# Altium schematic sheet SD-1_Schematic.SchDoc
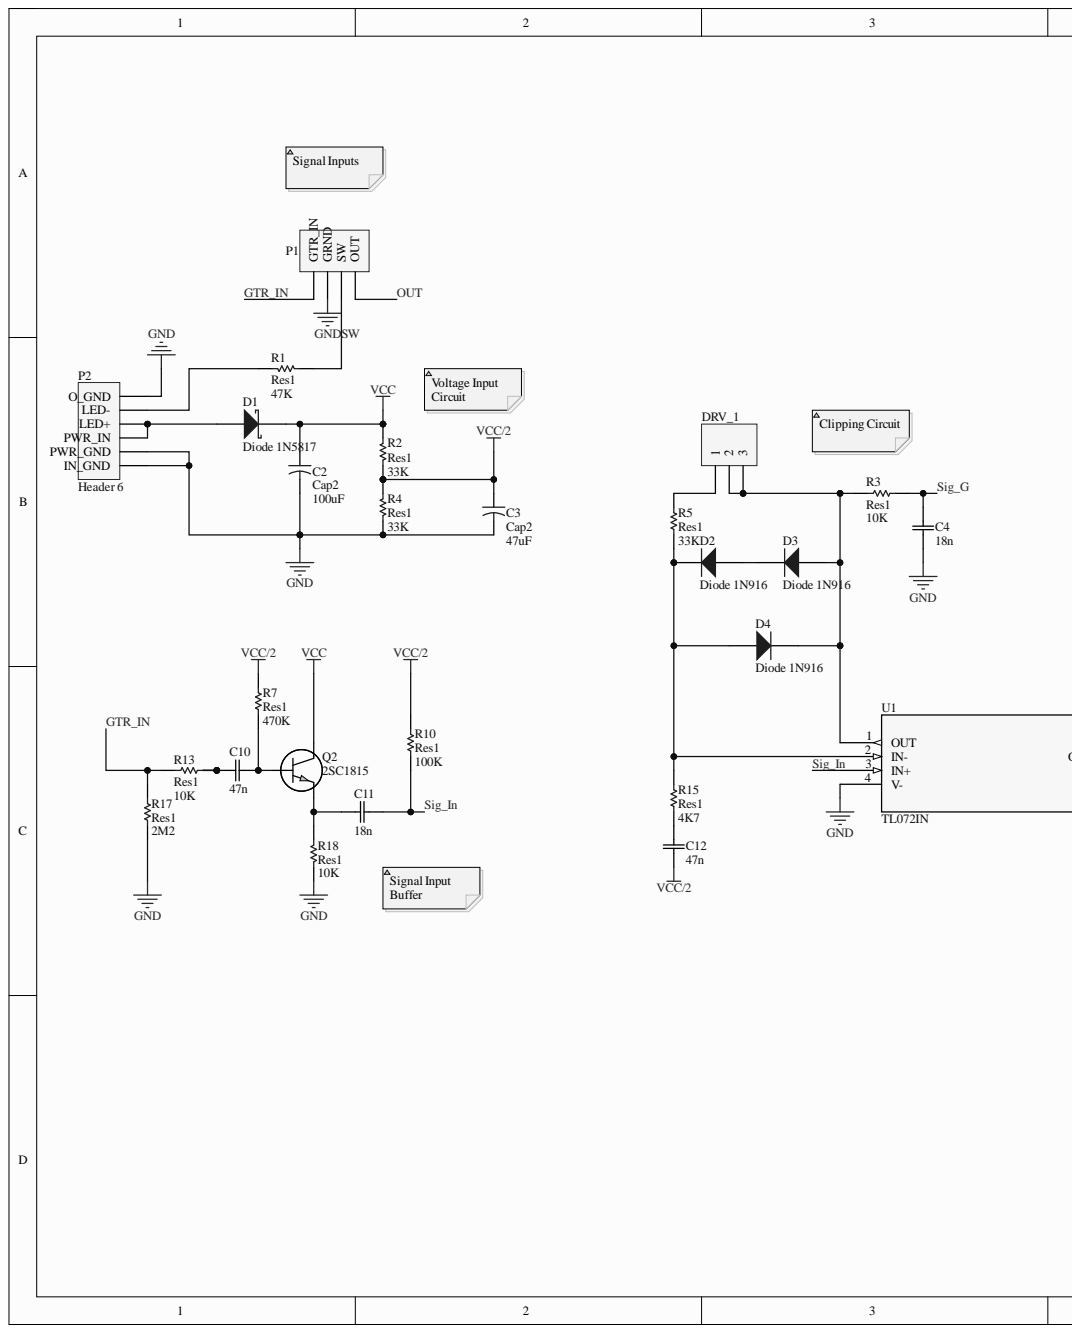
[diagram p0: SD-1_Schematic.SchDoc, left]
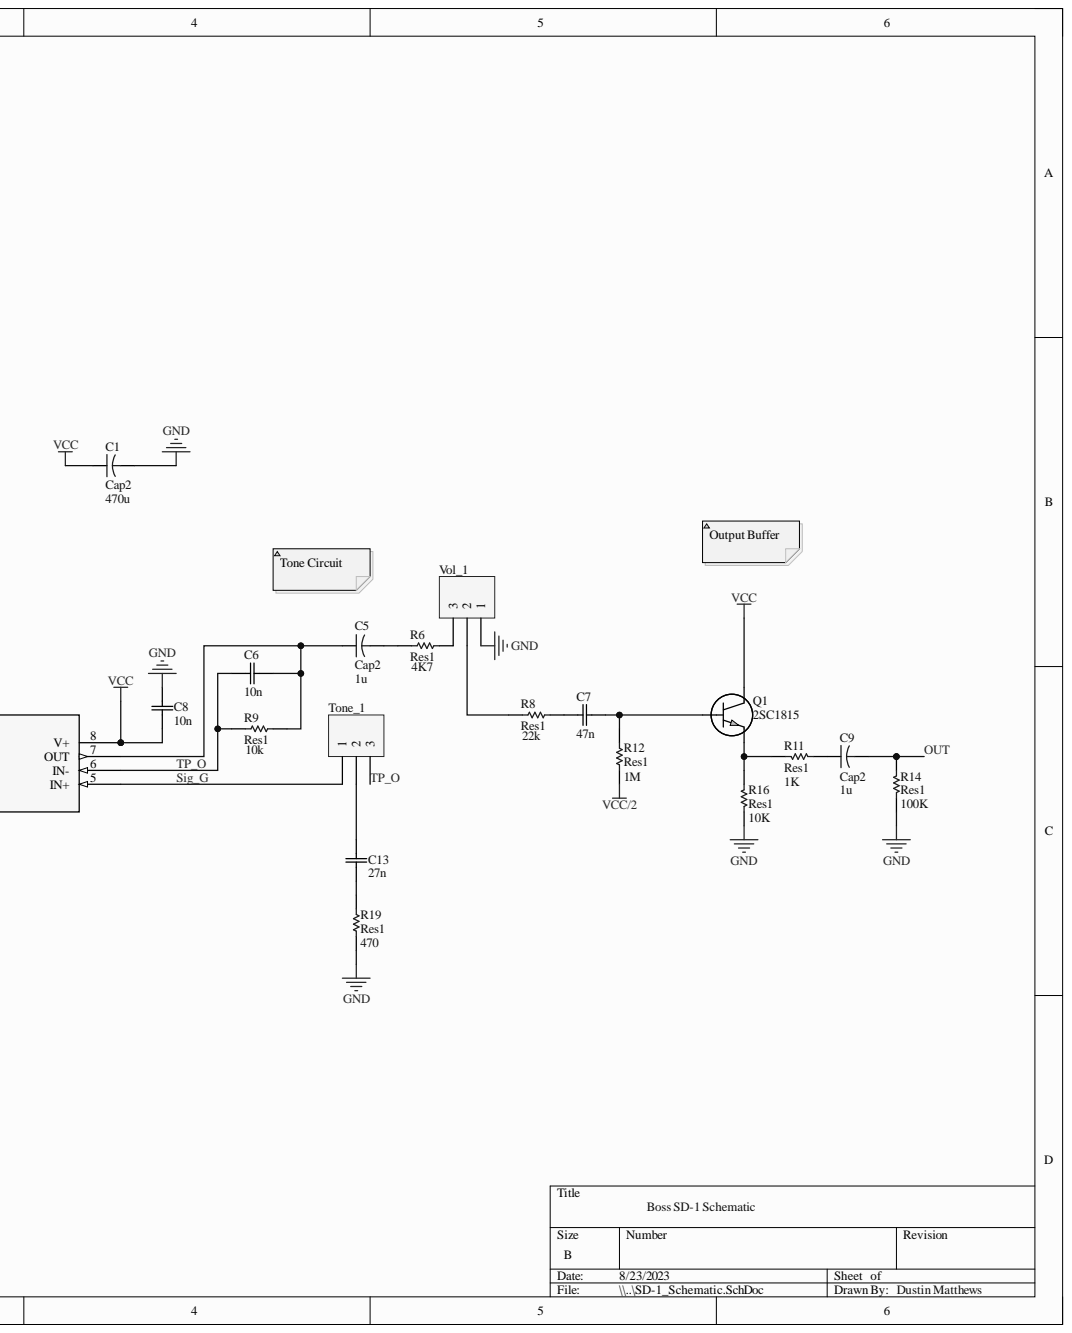
[diagram p1: SD-1_Schematic.SchDoc, right]

## Parts
C1  Cap2  value 470u  [CAPR5-4X5]
C2  Cap2  value 100uF  [CAPR5-4X5]
C3  Cap2  value 47uF  [CAPR5-4X5]
C4  18n  [CAP_B32529_04.5X09.5X07.3_TDK]
C5  Cap2  value 1u  [CAPR5-4X5]
C6  10n  [CAP_B32529_04.5X09.5X07.3_TDK]
C7  47n  [CAP_B32529_04.5X09.5X07.3_TDK]
C8  10n  [CAP_B32529_04.5X09.5X07.3_TDK]
C9  Cap2  value 1u  [CAPR5-4X5]
C10  47n  [CAP_B32529_04.5X09.5X07.3_TDK]
C11  18n  [CAP_B32529_04.5X09.5X07.3_TDK]
C12  47n  [CAP_B32529_04.5X09.5X07.3_TDK]
C13  27n  [CAP_B32529_04.5X09.5X07.3_TDK]
D1  Diode 1N5817  [DO-204AL]
D2  Diode 1N916  [DO-35]
D3  Diode 1N916  [DO-35]
D4  Diode 1N916  [DO-35]
DRV_1  Header 3  [HDR1X3]  (value not drawn)
P1  Header 4  [HDR1X4]  (value not drawn)
P2  Header 6  [HDR1X6]
Q1  2SC1815  [TO-92_4P2X5P2_CNS]
Q2  2SC1815  [TO-92_4P2X5P2_CNS]
R1  Res1  value 47K  [AXIAL-0.3]
R2  Res1  value 33K  [AXIAL-0.3]
R3  Res1  value 10K  [AXIAL-0.3]
R4  Res1  value 33K  [AXIAL-0.3]
R5  Res1  value 33K  [AXIAL-0.3]
R6  Res1  value 4K7  [AXIAL-0.3]
R7  Res1  value 470K  [AXIAL-0.3]
R8  Res1  value 22k  [AXIAL-0.3]
R9  Res1  value 10k  [AXIAL-0.3]
R10  Res1  value 100K  [AXIAL-0.3]
R11  Res1  value 1K  [AXIAL-0.3]
R12  Res1  value 1M  [AXIAL-0.3]
R13  Res1  value 10K  [AXIAL-0.3]
R14  Res1  value 100K  [AXIAL-0.3]
R15  Res1  value 4K7  [AXIAL-0.3]
R16  Res1  value 10K  [AXIAL-0.3]
R17  Res1  value 2M2  [AXIAL-0.3]
R18  Res1  value 10K  [AXIAL-0.3]
R19  Res1  value 470  [AXIAL-0.3]
Tone_1  Header 3  [HDR1X3]  (value not drawn)
U1  TL072IN  [DIP-8D11_STM]
Vol_1  Header 3  [HDR1X3]  (value not drawn)

## Nets
GND: C1.2 C2.2 C3.2 C4.2 C8.2 P1.2 P2.1 P2.2 P2.6 R4.1 R14.1 R16.1 R17.1 R18.1 R19.2 U1.4(V-) Vol_1.1
VCC: C1.1 C2.1 C8.1 D1.2 Q1.1 Q2.1 R2.2 U1.8(V+)
VCC/2: C3.1 C12.1 R2.1 R4.2 R7.2 R10.2 R12.1
GTR_IN: P1.1 R13.2 R17.2
OUT: C9.2 P1.4(OUT) R14.2
Sig_G: C4.1 R3.1 Tone_1.1 U1.5(IN+)
Sig_In: C11.1 R10.1 U1.3(IN+)
SW: P1.3(SW) R1.1
TP_O: C6.1 R9.1 Tone_1.3 U1.6(IN-)
NetC5_1: C5.1 C6.2 R9.2 U1.7(OUT)
NetC5_2: C5.2 R6.1
NetC7_1: C7.1 R8.2
NetC7_2: C7.2 Q1.2 R12.2
NetC9_1: C9.1 R11.1
NetC10_1: C10.1 R13.1
NetC10_2: C10.2 Q2.2 R7.1
NetC11_2: C11.2 Q2.3 R18.2
NetC12_2: C12.2 R15.1
NetC13_1: C13.1 Tone_1.2
NetC13_2: C13.2 R19.1
NetD1_1: D1.1 P2.3 P2.4(LED+)
NetD2_1: D2.1 D3.2
NetD2_2: D2.2 D4.1 R5.1 R15.2 U1.2(IN-)
NetD3_1: D3.1 D4.2 DRV_1.2 DRV_1.3 R3.2 U1.1(OUT)
NetDRV_1_1: DRV_1.1 R5.2
NetP2_5: P2.5(LED-) R1.2
NetQ1_3: Q1.3 R11.2 R16.2
NetR6_2: R6.2 Vol_1.3
NetR8_1: R8.1 Vol_1.2

## Library data of the parts
C1-C3 C5 C9 = Cap2: Capacitor; Component Kind: Standard; Library Name: Miscellaneous Devices.IntLib; PackageDescription: Capacitor; 2 Leads; PackageReference: CAPR5-4X5; Published: 23-Sep-2002; Signal Integrity: Cap; Simulation: CAP; Publisher: Altium Limited
C4 C6-C8 C10-C13 = B32529C0105J189: No Description Available; Mfr_Name: TDK; Component Kind: Standard; Library Name: B32529C0105J189.SchLib
D1 = Diode 11DQ03: Schottky Rectifier; Code_JEDEC: DO-204-AL; Component Kind: Standard; ComponentLink1Description: Manufacturer Link; ComponentLink1URL: http://www.irf.com/; ComponentLink2Description: Datasheet; ComponentLink2URL: http://www.irf.com/product-info/datasheets/data/11dq03.pdf; DatasheetVersion: Dec-1997; LatestRevisionDate: 17-Jul-2002; LatestRevisionNote: Re-released for DXP Platform.; Library Name: Miscellaneous Devices.IntLib; PackageDescription: Diode, Axial; Body 5.2 x 2.7 mm (LxDia. max), Lead Dia. 0.86 mm (max); PackageReference: DO-204AL; Published: 8-Jun-2000; Simulation: 11DQ03; Publisher: Altium Limited
D2-D4 = Diode 1N914: High Conductance Fast Diode; Code_JEDEC: DO-204-AH; Component Kind: Standard; ComponentLink1Description: Manufacturer Link; ComponentLink1URL: http://www.fairchildsemi.com/; ComponentLink2Description: Datasheet; ComponentLink2URL: http://www.fairchildsemi.com/ds/1N%2F1N4448.pdf; DatasheetVersion: 1997; LatestRevisionDate: 17-Jul-2002; LatestRevisionNote: Re-released for DXP Platform.; Library Name: Miscellaneous Devices.IntLib; PackageDescription: Diode, Axial; Body 3.8 x 1.9 mm (LxDia. max), Lead Dia. 0.53 mm (max); PackageReference: DO-35; Published: 8-Jun-2000; Signal Integrity: 1N914; Simulation: 1N914; Publisher: Altium Limited
DRV_1 Tone_1 Vol_1 = Header 3: Header, 3-Pin; Component Kind: Standard; LatestRevisionDate: 17-Jul-2002; LatestRevisionNote: Re-released for DXP Platform.; Library Name: Miscellaneous Connectors.IntLib; Signal Integrity: Connector; Publisher: Altium Limited
P1 = Header 4: Header, 4-Pin; Component Kind: Standard; LatestRevisionDate: 17-Jul-2002; LatestRevisionNote: Re-released for DXP Platform.; Library Name: Miscellaneous Connectors.IntLib; Signal Integrity: Connector; Publisher: Altium Limited
P2 = Header 6: Header, 6-Pin; Component Kind: Standard; LatestRevisionDate: 17-Jul-2002; LatestRevisionNote: Re-released for DXP Platform.; Library Name: Miscellaneous Connectors.IntLib; Signal Integrity: Connector; Publisher: Altium Limited
Q1 Q2 = 2SC1815: No Description Available; Mfr_Name: Central Semiconductor; Component Kind: Standard; Library Name: 2SC1815.SchLib
R1 = Res1: Resistor; Value: 47K; Component Kind: Standard; LatestRevisionDate: 17-Jul-2002; LatestRevisionNote: Re-released for DXP Platform.; Library Name: Miscellaneous Devices.IntLib; PackageDescription: Axial Device, Thru-Hole; 2 Leads; 0.3 in Pin Spacing; PackageReference: AXIAL-0.3; Published: 8-Jun-2000; Signal Integrity: Res; Simulation: RESISTOR; Publisher: Altium Limited
R2-R12 R14-R19 = Res1: Resistor; Component Kind: Standard; LatestRevisionDate: 17-Jul-2002; LatestRevisionNote: Re-released for DXP Platform.; Library Name: Miscellaneous Devices.IntLib; PackageDescription: Axial Device, Thru-Hole; 2 Leads; 0.3 in Pin Spacing; PackageReference: AXIAL-0.3; Published: 8-Jun-2000; Signal Integrity: Res; Simulation: RESISTOR; Publisher: Altium Limited
R13 = Res1: Resistor; Value: 10K; Component Kind: Standard; LatestRevisionDate: 17-Jul-2002; LatestRevisionNote: Re-released for DXP Platform.; Library Name: Miscellaneous Devices.IntLib; PackageDescription: Axial Device, Thru-Hole; 2 Leads; 0.3 in Pin Spacing; PackageReference: AXIAL-0.3; Published: 8-Jun-2000; Signal Integrity: Res; Simulation: RESISTOR; Publisher: Altium Limited
U1 = TL072IN: No Description Available; Mfr_Name: STMicroelectronics; Component Kind: Standard; Library Name: TL072IN.IntLib; RefDes: RefDes; Type: Type
